# Altium schematic sheet ECU-2.SchDoc
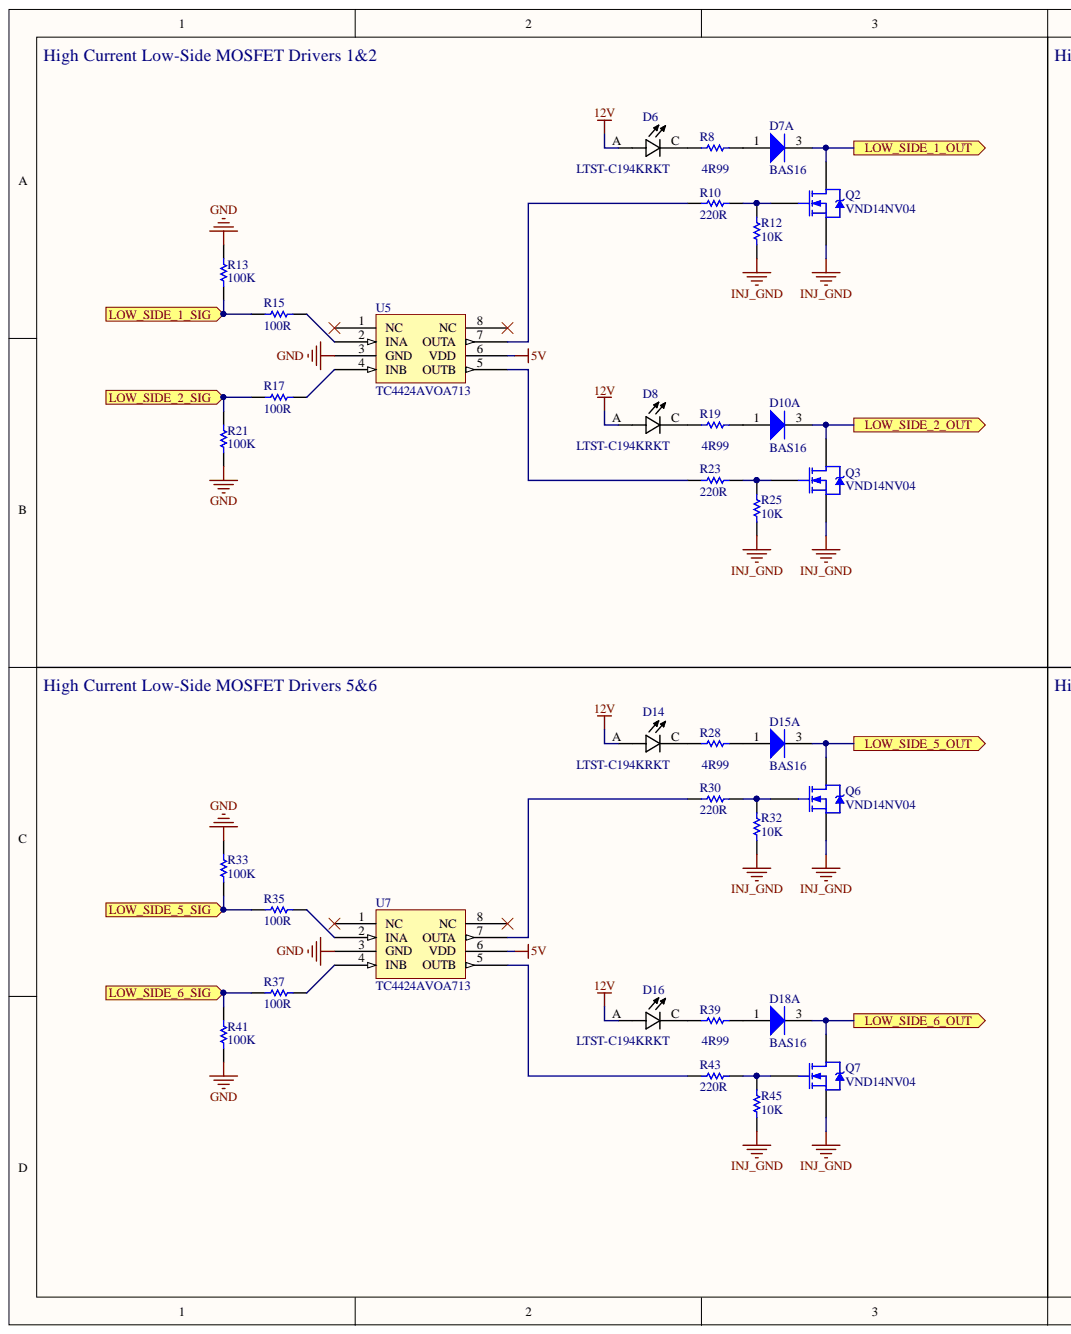
[diagram p0: ECU-2.SchDoc, left]
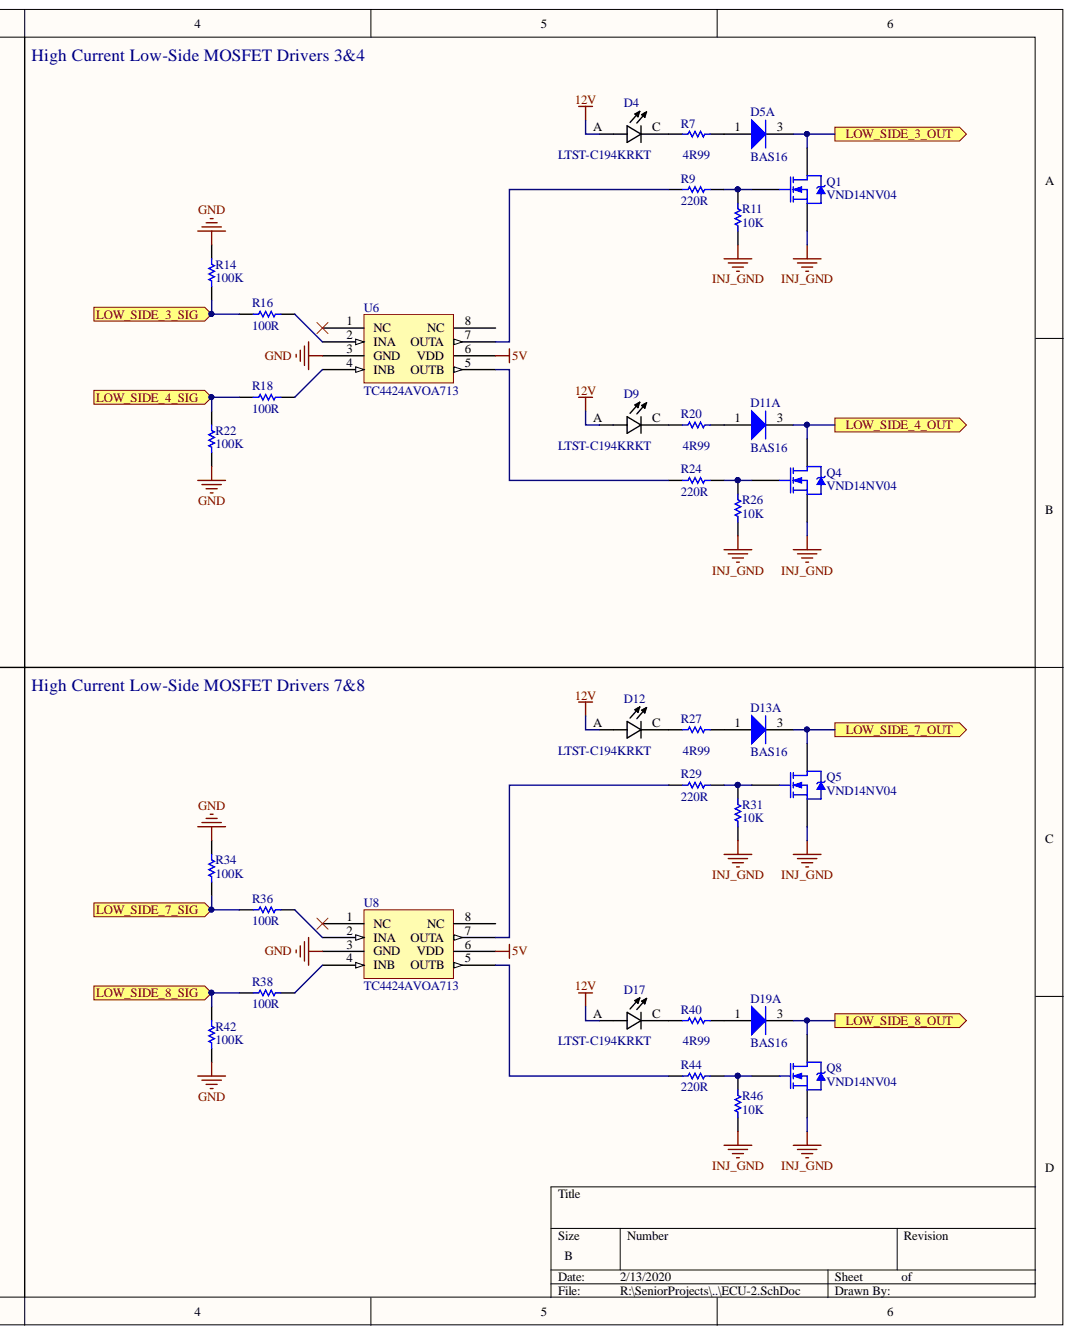
[diagram p1: ECU-2.SchDoc, right]

## Parts
D4  LTST-C194KRKT  [LEDC1608X35N_LTST-C194KRKT]
D5  BAS16  [NXP-SOT23-3_V]  (part A)
D6  LTST-C194KRKT  [LEDC1608X35N_LTST-C194KRKT]
D7  BAS16  [NXP-SOT23-3_V]  (part A)
D8  LTST-C194KRKT  [LEDC1608X35N_LTST-C194KRKT]
D9  LTST-C194KRKT  [LEDC1608X35N_LTST-C194KRKT]
D10  BAS16  [NXP-SOT23-3_V]  (part A)
D11  BAS16  [NXP-SOT23-3_V]  (part A)
D12  LTST-C194KRKT  [LEDC1608X35N_LTST-C194KRKT]
D13  BAS16  [NXP-SOT23-3_V]  (part A)
D14  LTST-C194KRKT  [LEDC1608X35N_LTST-C194KRKT]
D15  BAS16  [NXP-SOT23-3_V]  (part A)
D16  LTST-C194KRKT  [LEDC1608X35N_LTST-C194KRKT]
D17  LTST-C194KRKT  [LEDC1608X35N_LTST-C194KRKT]
D18  BAS16  [NXP-SOT23-3_V]  (part A)
D19  BAS16  [NXP-SOT23-3_V]  (part A)
Q1  VND14NV04  [VND14NV04]
Q2  VND14NV04  [VND14NV04]
Q3  VND14NV04  [VND14NV04]
Q4  VND14NV04  [VND14NV04]
Q5  VND14NV04  [VND14NV04]
Q6  VND14NV04  [VND14NV04]
Q7  VND14NV04  [VND14NV04]
Q8  VND14NV04  [VND14NV04]
R7  4R99  [RESC0603(1608)_M]
R8  4R99  [RESC0603(1608)_M]
R9  220R  [RESC0603(1608)_L]
R10  220R  [RESC0603(1608)_L]
R11  10K  [RESC0603(1608)_N]
R12  10K  [RESC0603(1608)_N]
R13  100K  [RESC0603(1608)_N]
R14  100K  [RESC0603(1608)_N]
R15  100R  [RESC0603(1608)_M]
R16  100R  [RESC0603(1608)_M]
R17  100R  [RESC0603(1608)_M]
R18  100R  [RESC0603(1608)_M]
R19  4R99  [RESC0603(1608)_M]
R20  4R99  [RESC0603(1608)_M]
R21  100K  [RESC0603(1608)_N]
R22  100K  [RESC0603(1608)_N]
R23  220R  [RESC0603(1608)_L]
R24  220R  [RESC0603(1608)_L]
R25  10K  [RESC0603(1608)_N]
R26  10K  [RESC0603(1608)_N]
R27  4R99  [RESC0603(1608)_M]
R28  4R99  [RESC0603(1608)_M]
R29  220R  [RESC0603(1608)_L]
R30  220R  [RESC0603(1608)_L]
R31  10K  [RESC0603(1608)_N]
R32  10K  [RESC0603(1608)_N]
R33  100K  [RESC0603(1608)_N]
R34  100K  [RESC0603(1608)_N]
R35  100R  [RESC0603(1608)_M]
R36  100R  [RESC0603(1608)_M]
R37  100R  [RESC0603(1608)_M]
R38  100R  [RESC0603(1608)_M]
R39  4R99  [RESC0603(1608)_M]
R40  4R99  [RESC0603(1608)_M]
R41  100K  [RESC0603(1608)_N]
R42  100K  [RESC0603(1608)_N]
R43  220R  [RESC0603(1608)_L]
R44  220R  [RESC0603(1608)_L]
R45  10K  [RESC0603(1608)_N]
R46  10K  [RESC0603(1608)_N]
U5  TC4424AVOA713  [TC4424AVOA713]
U6  TC4424AVOA713  [TC4424AVOA713]
U7  TC4424AVOA713  [TC4424AVOA713]
U8  TC4424AVOA713  [TC4424AVOA713]

## Ports
LOW_SIDE_1_OUT, LOW_SIDE_1_SIG, LOW_SIDE_2_OUT, LOW_SIDE_2_SIG, LOW_SIDE_3_OUT, LOW_SIDE_3_SIG, LOW_SIDE_4_OUT, LOW_SIDE_4_SIG, LOW_SIDE_5_OUT, LOW_SIDE_5_SIG, LOW_SIDE_6_OUT, LOW_SIDE_6_SIG, LOW_SIDE_7_OUT, LOW_SIDE_7_SIG, LOW_SIDE_8_OUT, LOW_SIDE_8_SIG

## Nets
5V: U5.6(VDD) U6.6(VDD) U7.6(VDD) U8.6(VDD)
12V: D4.A D6.A D8.A D9.A D12.A D14.A D16.A D17.A
GND: R13.1 R14.1 R21.1 R22.1 R33.1 R34.1 R41.1 R42.1 U5.3(GND) U6.3(GND) U7.3(GND) U8.3(GND)
INJ_GND: Q1.3 Q2.3 Q3.3 Q4.3 Q5.3 Q6.3 Q7.3 Q8.3 R11.1 R12.1 R25.1 R26.1 R31.1 R32.1 R45.1 R46.1
LOW_SIDE_1_OUT: D7.3 Q2.4 ; port LOW_SIDE_1_OUT
LOW_SIDE_1_SIG: R13.2 R15.1 ; port LOW_SIDE_1_SIG
LOW_SIDE_2_OUT: D10.3 Q3.4 ; port LOW_SIDE_2_OUT
LOW_SIDE_2_SIG: R17.1 R21.2 ; port LOW_SIDE_2_SIG
LOW_SIDE_3_OUT: D5.3 Q1.4 ; port LOW_SIDE_3_OUT
LOW_SIDE_3_SIG: R14.2 R16.1 ; port LOW_SIDE_3_SIG
LOW_SIDE_4_OUT: D11.3 Q4.4 ; port LOW_SIDE_4_OUT
LOW_SIDE_4_SIG: R18.1 R22.2 ; port LOW_SIDE_4_SIG
LOW_SIDE_5_OUT: D15.3 Q6.4 ; port LOW_SIDE_5_OUT
LOW_SIDE_5_SIG: R33.2 R35.1 ; port LOW_SIDE_5_SIG
LOW_SIDE_6_OUT: D18.3 Q7.4 ; port LOW_SIDE_6_OUT
LOW_SIDE_6_SIG: R37.1 R41.2 ; port LOW_SIDE_6_SIG
LOW_SIDE_7_OUT: D13.3 Q5.4 ; port LOW_SIDE_7_OUT
LOW_SIDE_7_SIG: R34.2 R36.1 ; port LOW_SIDE_7_SIG
LOW_SIDE_8_OUT: D19.3 Q8.4 ; port LOW_SIDE_8_OUT
LOW_SIDE_8_SIG: R38.1 R42.2 ; port LOW_SIDE_8_SIG
NetD4_C: D4.C R7.1
NetD5_1: D5.1 R7.2
NetD6_C: D6.C R8.1
NetD7_1: D7.1 R8.2
NetD8_C: D8.C R19.1
NetD9_C: D9.C R20.1
NetD10_1: D10.1 R19.2
NetD11_1: D11.1 R20.2
NetD12_C: D12.C R27.1
NetD13_1: D13.1 R27.2
NetD14_C: D14.C R28.1
NetD15_1: D15.1 R28.2
NetD16_C: D16.C R39.1
NetD17_C: D17.C R40.1
NetD18_1: D18.1 R39.2
NetD19_1: D19.1 R40.2
NetQ1_1: Q1.1 R9.2 R11.2
NetQ2_1: Q2.1 R10.2 R12.2
NetQ3_1: Q3.1 R23.2 R25.2
NetQ4_1: Q4.1 R24.2 R26.2
NetQ5_1: Q5.1 R29.2 R31.2
NetQ6_1: Q6.1 R30.2 R32.2
NetQ7_1: Q7.1 R43.2 R45.2
NetQ8_1: Q8.1 R44.2 R46.2
NetR9_1: R9.1 U6.7(OUTA)
NetR10_1: R10.1 U5.7(OUTA)
NetR15_2: R15.2 U5.2(INA)
NetR16_2: R16.2 U6.2(INA)
NetR17_2: R17.2 U5.4(INB)
NetR18_2: R18.2 U6.4(INB)
NetR23_1: R23.1 U5.5(OUTB)
NetR24_1: R24.1 U6.5(OUTB)
NetR29_1: R29.1 U8.7(OUTA)
NetR30_1: R30.1 U7.7(OUTA)
NetR35_2: R35.2 U7.2(INA)
NetR36_2: R36.2 U8.2(INA)
NetR37_2: R37.2 U7.4(INB)
NetR38_2: R38.2 U8.4(INB)
NetR43_1: R43.1 U7.5(OUTB)
NetR44_1: R44.1 U8.5(OUTB)

Not connected: U5 1(NC) 8(NC); U6 1(NC) 8(NC); U7 1(NC) 8(NC); U8 1(NC) 8(NC)

## Library data of the parts
D4 D6 D8 D9 D12 D14 D16 D17 = LTST-C194KRKT: Red 631nm LED Indication - Discrete 2V 0603 _1608 Metric_; MF: Lite-On Inc.; Component Kind: Standard; Digi-Key_Part_Number: 160-1835-1-ND; Digi-Key_Purchase_URL: https://www.digikey.com/product-detail/en/lite-on-inc/LTST-C194KRKT/160-1835-1-ND/2356232?utm_source=snapeda&utm_medium=aggregator&utm_campaign=symbol; Library Name: ECU.SchLib; MP: LTST-C194KRKT; Package: 0603 Lite-On; SnapEDA_Link: https://www.snapeda.com/parts/LTST-C194KRKT/Lite-On%20Inc./view-part/370565/?ref=snap
D5 D7 D10 D11 D13 D15 D18 D19 = CMP-0648-00001-1: High-speed Switching Diode, 100 V, 4 ns, -65 to 150 degC, 3-Pin SOT23, RoHS, Tape and Reel; Manufacturer: NXP Semiconductors; Cd (pF): 1.5; IF (mA): 215; IFRM (mA): 500; Ptot (mW): 250; Rth (j-t) (K/W): 330; trr (ns): 4; VFR (V): 1.75; VR (V): 100; Component Kind: Standard; ComponentLink1Description: Manufacturer URL; ComponentLink1URL: http://www.nxp.com/; ComponentLink2Description: Datasheet; ComponentLink2URL: http://www.nxp.com/documents/data_sheet/BAS16_SER.pdf; DatasheetVersion: Rev. 05; Library Name: Altium Content Vault; Mounting Technology: Surface Mount; PackageDescription: 3-Pin Plastic Surface-mounted Package, Body 1.3 x 2.9 mm, Pitch 0.95 mm; PackageReference: SOT23-3; Packing: Tape and Reel; PartNumber: BAS16; RoHS: TRUE; Rth (j-a) (K/W): 500; Temperature Range: -65 to 150 degC; VRRM (V): 100
R7 R8 R19 R20 R27 R28 R39 R40 = CMP-1012-00250-1: 4R99 0.1W 1% 0603 (1608 Metric)  SMD; Case-EIA: 0603; Case-Metric: 1608; Value: 4.99 Ohm; Component Kind: Standard; Library Name: Altium Content Vault; Power: 0.1 W; Technology: SMT; Tolerance: 1 %
R9 R10 R23 R24 R29 R30 R43 R44 = CMP-1012-00433-1: 220R 0.1W 1% 0603 (1608 Metric)  SMD; Case-EIA: 0603; Case-Metric: 1608; Value: 220.00 Ohm; Component Kind: Standard; Library Name: Altium Content Vault; Power: 0.1 W; Technology: SMT; Tolerance: 1 %
R11 R12 R25 R26 R31 R32 R45 R46 = CMP-1012-00623-1: 10K 0.1W 1% 0603 (1608 Metric)  SMD; Case-EIA: 0603; Case-Metric: 1608; Value: 10000.00 Ohm; Component Kind: Standard; Library Name: Altium Content Vault; Power: 0.1 W; Technology: SMT; Tolerance: 1 %
R13 R14 R21 R22 R33 R34 R41 R42 = CMP-1012-00736-1: 100K 0.1W 1% 0603 (1608 Metric)  SMD; Case-EIA: 0603; Case-Metric: 1608; Value: 100000.00 Ohm; Component Kind: Standard; Library Name: Altium Content Vault; Power: 0.1 W; Technology: SMT; Tolerance: 1 %
R15-R18 R35-R38 = CMP-1012-00397-1: 100R 0.1W 1% 0603 (1608 Metric)  SMD; Case-EIA: 0603; Case-Metric: 1608; Value: 100.00 Ohm; Component Kind: Standard; Library Name: Altium Content Vault; Power: 0.1 W; Technology: SMT; Tolerance: 1 %
Q1-Q8 = VND14NV04: Component Kind: Standard; Description: VND14NV04; Library Name: ECU.SchLib
U5-U8 = TC4424AVOA713: Component Kind: Standard; Description: TC4424AVOA713; Library Name: ECU.SchLib
